# Altium schematic sheet 03 B2B and Ethernet PHY.SchDoc("03 B2B and Ethernet PHY")
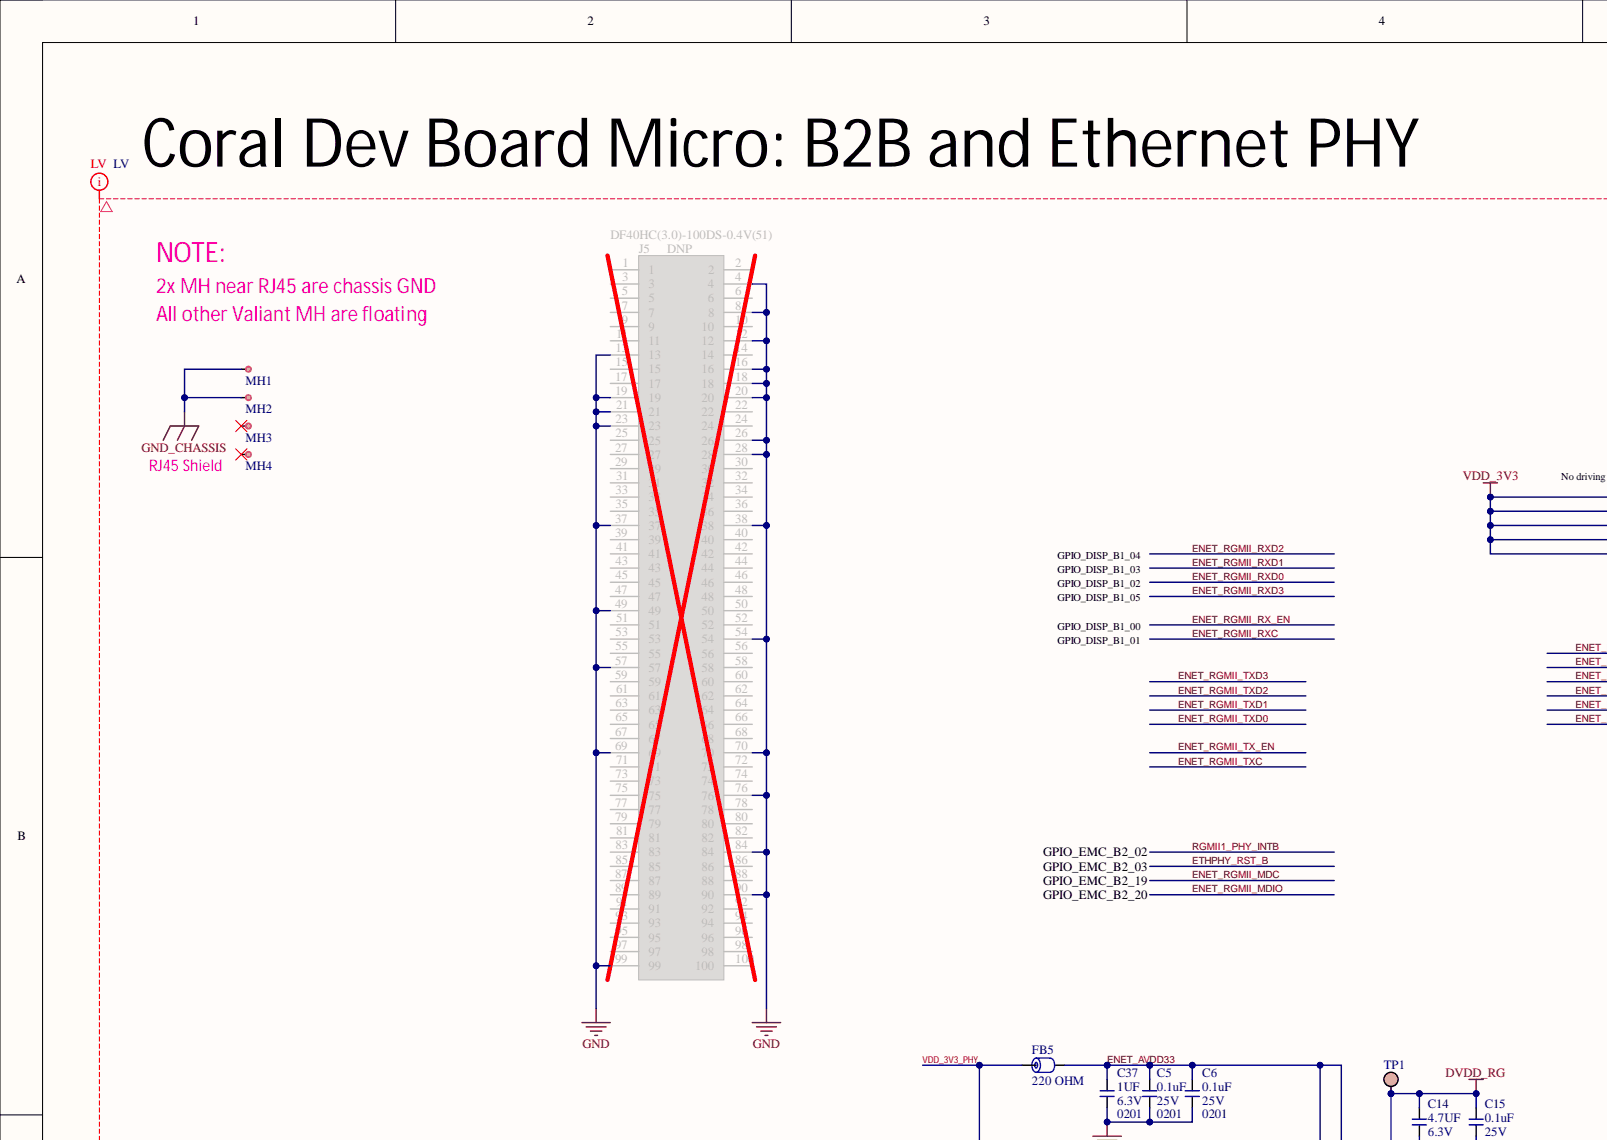
[diagram p0: 03 B2B and Ethernet PHY.SchDoc, top left]
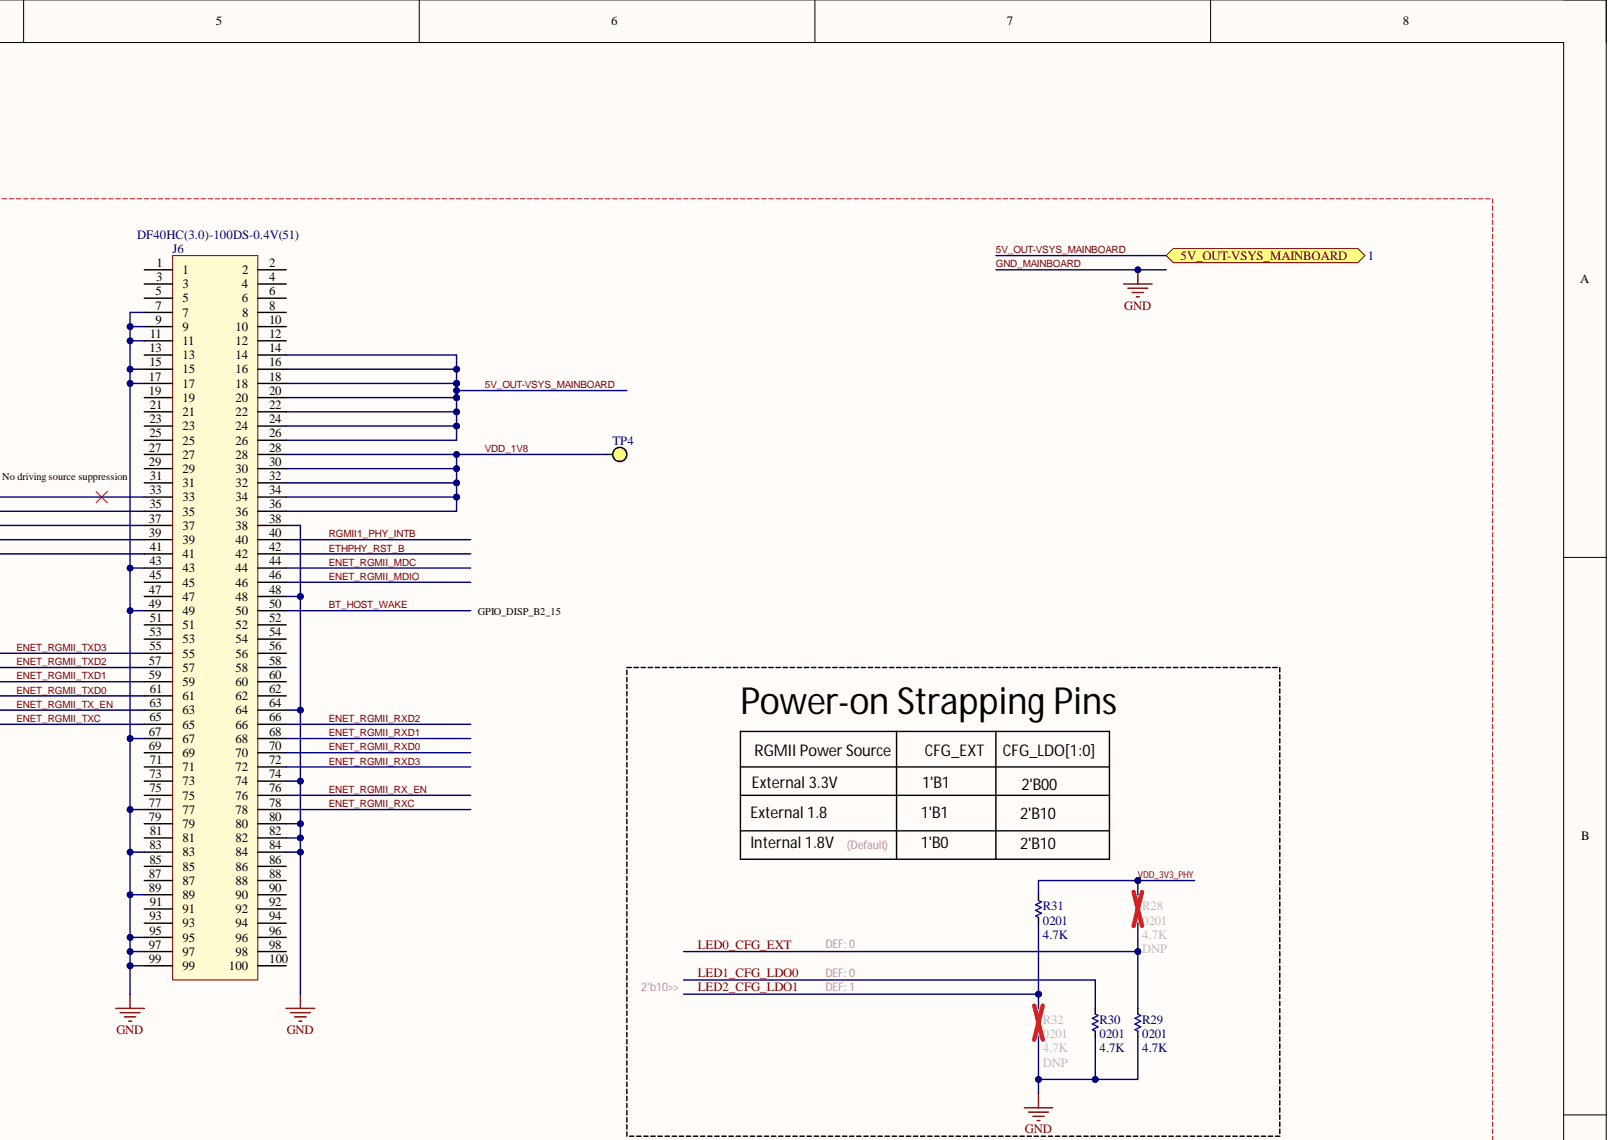
[diagram p1: 03 B2B and Ethernet PHY.SchDoc, top right]
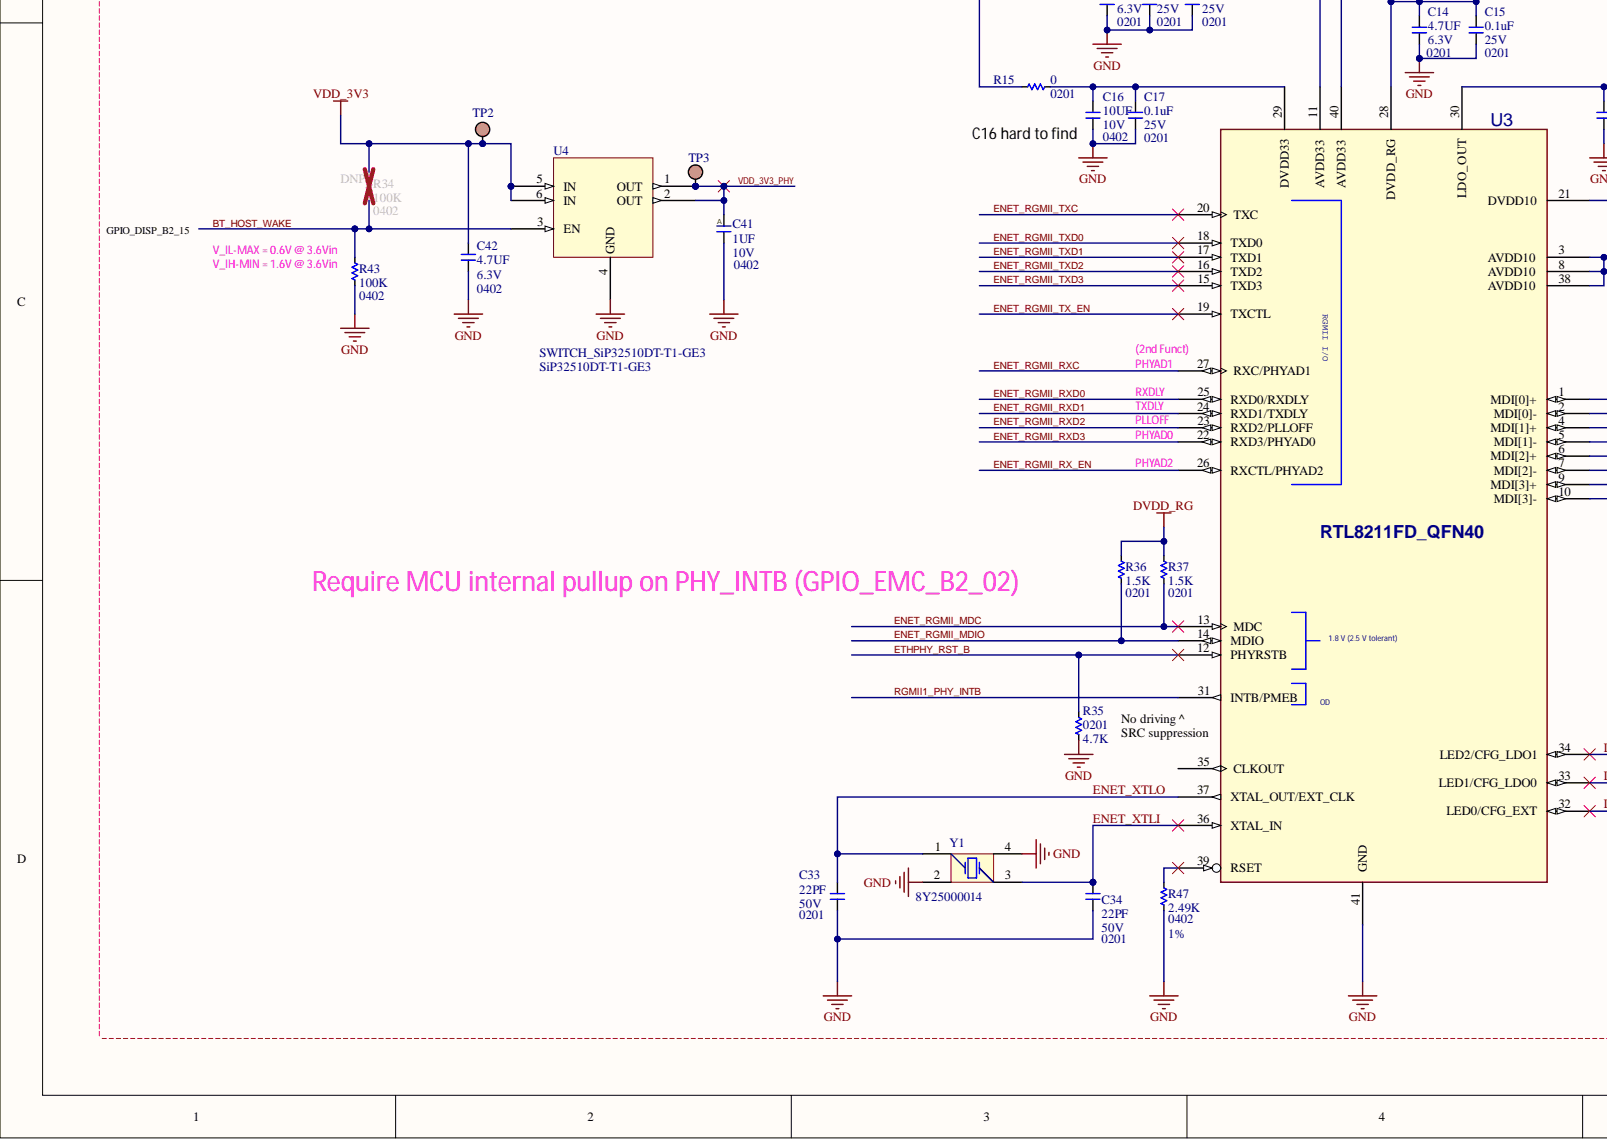
[diagram p2: 03 B2B and Ethernet PHY.SchDoc, bottom left]
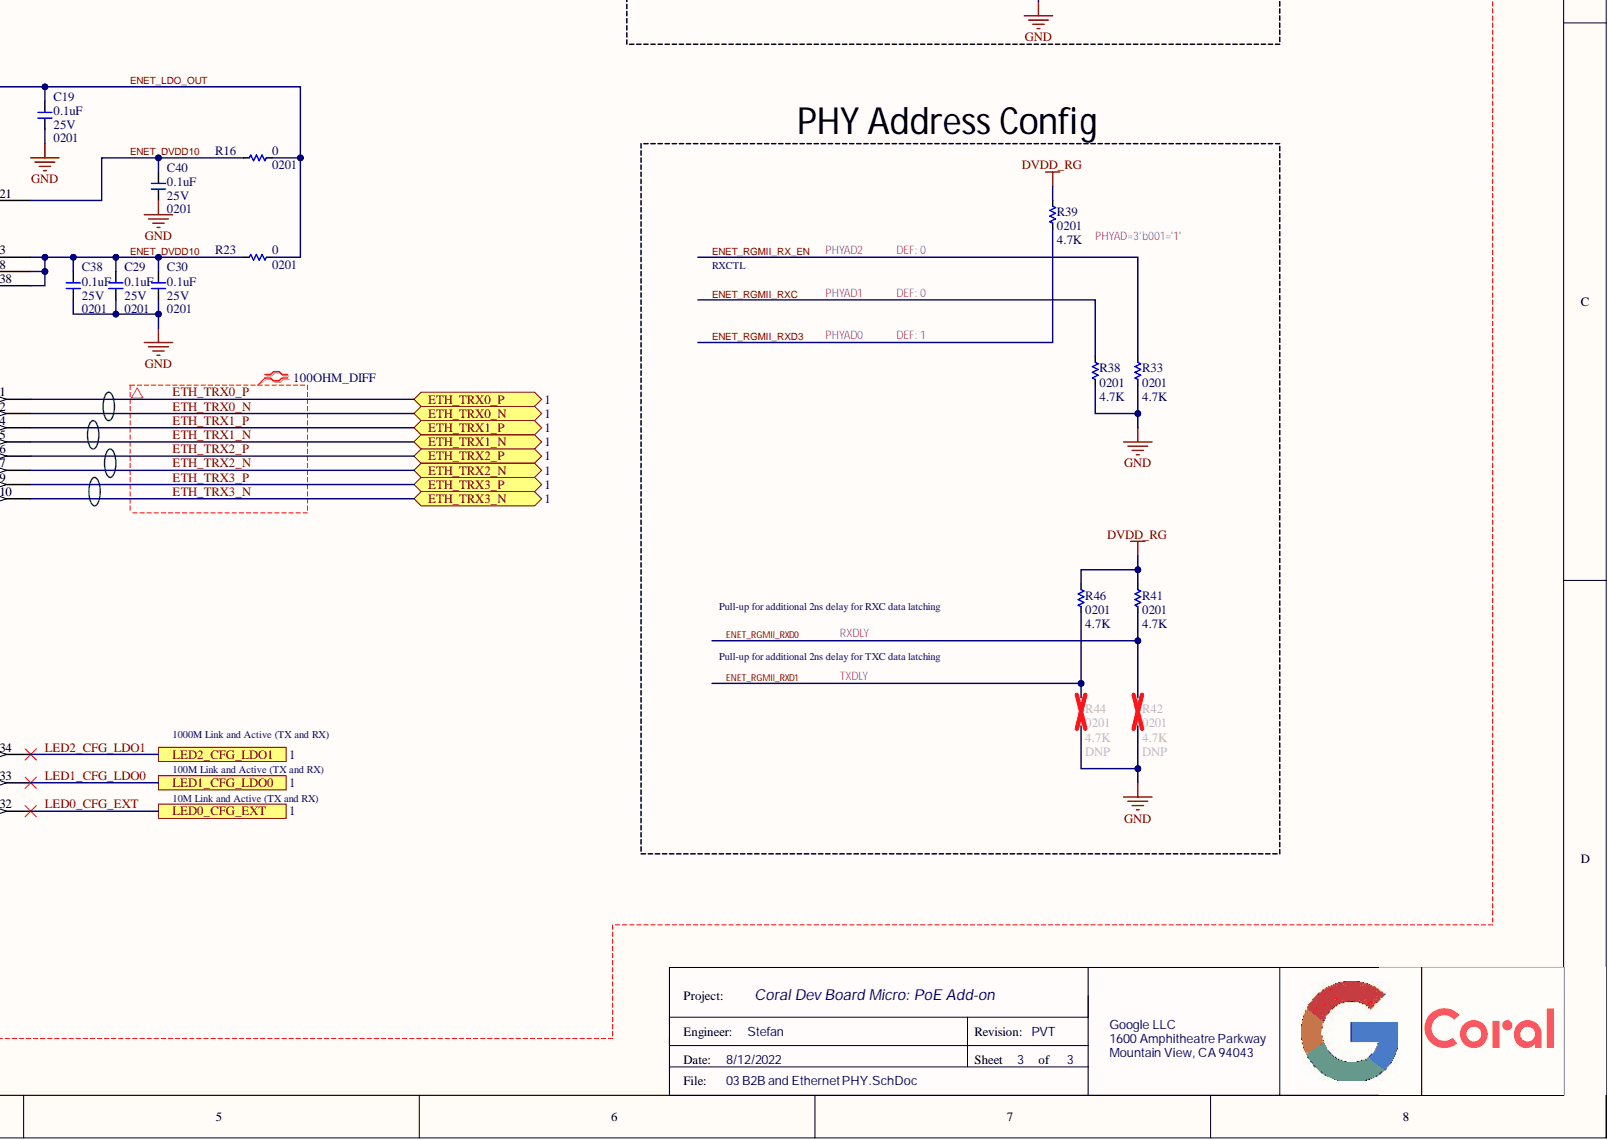
[diagram p3: 03 B2B and Ethernet PHY.SchDoc, bottom right]

## Parts
C5  value 0.1uF  Package: 0201  Voltage: 25V  [C0201Y]  (hidden comment: __Template_Cap)
C6  value 0.1uF  Package: 0201  Voltage: 25V  [C0201Y]  (hidden comment: __Template_Cap)
C14  value 4.7UF  Package: 0201  Voltage: 6.3V  [C0201Y]  (hidden comment: __Template_Cap)
C15  value 0.1uF  Package: 0201  Voltage: 25V  [C0201Y]  (hidden comment: __Template_Cap)
C16  value 10UF  Package: 0402  Voltage: 10V  [C0402]  (hidden comment: __Template_Cap)
C17  value 0.1uF  Package: 0201  Voltage: 25V  [C0201Y]  (hidden comment: __Template_Cap)
C19  value 0.1uF  Package: 0201  Voltage: 25V  [C0201Y]  (hidden comment: __Template_Cap)
C29  value 0.1uF  Package: 0201  Voltage: 25V  [C0201Y]  (hidden comment: __Template_Cap)
C30  value 0.1uF  Package: 0201  Voltage: 25V  [C0201Y]  (hidden comment: __Template_Cap)
C33  value 22PF  Package: 0201  Voltage: 50V  [C0201]  (hidden comment: __Template_Cap)
C34  value 22PF  Package: 0201  Voltage: 50V  [C0201]  (hidden comment: __Template_Cap)
C37  value 1UF  Package: 0201  Voltage: 6.3V  [C0201_H0.39MM]  (hidden comment: __Template_Cap)
C38  value 0.1uF  Package: 0201  Voltage: 25V  [C0201Y]  (hidden comment: __Template_Cap)
C40  value 0.1uF  Package: 0201  Voltage: 25V  [C0201Y]  (hidden comment: __Template_Cap)
C41  value 1UF  Package: 0402  Voltage: 10V  [C0402]  (hidden comment: __Template_Cap_Auto)
C42  value 4.7UF  Voltage: 6.3V  [C0402]  (hidden comment: __Template_Cap)
FB5  value 220 OHM  [L0402_H0.55MM]  (hidden comment: __Template_Ferrite_Bead)
J5  MFG P/N: DF40HC(3.0)-100DS-0.4V(51)  [HIROSE_DF40HC(3.0)-100DS-0.4V(51)]
J6  MFG P/N: DF40HC(3.0)-100DS-0.4V(51)  [HIROSE_DF40HC(3.0)-100DS-0.4V(51)]
MH1  PEM_SMTSO-M3-2ET  [WE_9774030951R]  (value not drawn)
MH2  PEM_SMTSO-M3-2ET  [WE_9774030951R]  (value not drawn)
MH3  PEM_SMTSO-M3-2ET  [WE_9774030951R]  (value not drawn)
MH4  PEM_SMTSO-M3-2ET  [WE_9774030951R]  (value not drawn)
R15  value 0  Package: 0201  [R0201]
R16  value 0  Package: 0201  [R0201]
R23  value 0  Package: 0201  [R0201]
R28  value 4.7K  Package: 0201  [R0201]
R29  value 4.7K  Package: 0201  [R0201]
R30  value 4.7K  Package: 0201  [R0201]
R31  value 4.7K  Package: 0201  [R0201]
R32  value 4.7K  Package: 0201  [R0201]
R33  value 4.7K  Package: 0201  [R0201]
R34  value 100K  Package: 0402  [R0402]
R35  value 4.7K  Package: 0201  [R0201]
R36  value 1.5K  Package: 0201  [R0201]
R37  value 1.5K  Package: 0201  [R0201]
R38  value 4.7K  Package: 0201  [R0201]
R39  value 4.7K  Package: 0201  [R0201]
R41  value 4.7K  Package: 0201  [R0201]
R42  value 4.7K  Package: 0201  [R0201]
R43  value 100K  Package: 0402  [R0402]
R44  value 4.7K  Package: 0201  [R0201]
R46  value 4.7K  Package: 0201  [R0201]
R47  value 2.49K  Package: 0402  [R0402]
TP1  TESTPAD_30MIL  [TESTPAD_SMT_30MIL]  (value not drawn)  (no BOM)
TP2  TESTPAD_30MIL  [TESTPAD_SMT_30MIL]  (value not drawn)  (no BOM)
TP3  TESTPAD_30MIL  [TESTPAD_SMT_30MIL]  (value not drawn)  (no BOM)
TP4  TESTPAD_30MIL  [TESTPAD_SMT_30MIL]  (value not drawn)  (no BOM)
U3  RTL8211FD_QFN40  [QFN40_127P600-8N]
U4  SWITCH_SiP32510DT-T1-GE3  MFG P/N: SiP32510DT-T1-GE3  [SOT95P285X110-6]
Y1  8Y25000014  [DFN130P250X50-4N]

## Ports
5V_OUT-VSYS_MAINBOARD, ETH_TRX0_N, ETH_TRX0_P, ETH_TRX1_N, ETH_TRX1_P, ETH_TRX2_N, ETH_TRX2_P, ETH_TRX3_N, ETH_TRX3_P, LED0_CFG_EXT, LED1_CFG_LDO0, LED2_CFG_LDO1

## Nets
DVDD_RG: C14.1 C15.1 R36.2 R37.2 R39.2 R41.2 R46.2 TP1.1 U3.28(DVDD_RG)
GND_CHASSIS: MH1.1 MH2.1
VDD_3V3: C42.1 J6.33 J6.35 J6.37 J6.39 J6.41 R34.2 TP2.1 U4.5(IN) U4.6(IN)
5V_OUT-VSYS_MAINBOARD: J6.14 J6.16 J6.18 J6.20 J6.22 J6.24 J6.26 ; port 5V_OUT-VSYS_MAINBOARD
BT_HOST_WAKE: J6.50 R34.1 R43.2 U4.3(EN)
ENET_AVDD33: C5.1 C6.1 C37.1 FB5.2 U3.11(AVDD33) U3.40(AVDD33)
ENET_DVDD10: C29.1 C30.1 C38.1 C40.1 R16.1 R23.1 U3.3(AVDD10) U3.8(AVDD10) U3.21(DVDD10) U3.38(AVDD10)
ENET_LDO_OUT: C19.1 R16.2 R23.2 U3.30(LDO_OUT)
ENET_RGMII_MDC: J6.44 R37.1 U3.13(MDC)
ENET_RGMII_MDIO: J6.46 R36.1 U3.14(MDIO)
ENET_RGMII_RX_EN: J6.76 R33.2 U3.26(RXCTL/PHYAD2)
ENET_RGMII_RXC: J6.78 R38.2 U3.27(RXC/PHYAD1)
ENET_RGMII_RXD0: J6.70 R41.1 R42.2 U3.25(RXD0/RXDLY)
ENET_RGMII_RXD1: J6.68 R44.2 R46.1 U3.24(RXD1/TXDLY)
ENET_RGMII_RXD2: J6.66 U3.23(RXD2/PLLOFF)
ENET_RGMII_RXD3: J6.72 R39.1 U3.22(RXD3/PHYAD0)
ENET_RGMII_TX_EN: J6.63 U3.19(TXCTL)
ENET_RGMII_TXC: J6.65 U3.20(TXC)
ENET_RGMII_TXD0: J6.61 U3.18(TXD0)
ENET_RGMII_TXD1: J6.59 U3.17(TXD1)
ENET_RGMII_TXD2: J6.57 U3.16(TXD2)
ENET_RGMII_TXD3: J6.55 U3.15(TXD3)
ENET_XTLI: C34.1 U3.36(XTAL_IN) Y1.3
ENET_XTLO: C33.1 U3.37(XTAL_OUT/EXT_CLK) Y1.1
ETH_TRX0_N: U3.2(MDI[0]-) ; port ETH_TRX0_N
ETH_TRX0_P: U3.1(MDI[0]+) ; port ETH_TRX0_P
ETH_TRX1_N: U3.5(MDI[1]-) ; port ETH_TRX1_N
ETH_TRX1_P: U3.4(MDI[1]+) ; port ETH_TRX1_P
ETH_TRX2_N: U3.7(MDI[2]-) ; port ETH_TRX2_N
ETH_TRX2_P: U3.6(MDI[2]+) ; port ETH_TRX2_P
ETH_TRX3_N: U3.10(MDI[3]-) ; port ETH_TRX3_N
ETH_TRX3_P: U3.9(MDI[3]+) ; port ETH_TRX3_P
ETHPHY_RST_B: J6.42 R35.1 U3.12(PHYRSTB)
GND_MAINBOARD: C5.2 C6.2 C14.2 C15.2 C16.2 C17.2 C19.2 C29.2 C30.2 C33.2 C34.2 C37.2 C38.2 C40.2 C41.2 C42.2 J5.4 J5.8 J5.12 J5.13 J5.16 J5.18 J5.19 J5.20 J5.21 J5.23 J5.26 J5.28 J5.37 J5.38 J5.49 J5.54 J5.57 J5.69 J5.70 J5.76 J5.84 J5.90 J5.99 J6.7 J6.9 J6.11 J6.15 J6.17 J6.38 J6.43 J6.48 J6.49 J6.64 J6.67 J6.74 J6.77 J6.80 J6.82 J6.83 J6.84 J6.89 J6.95 J6.97 J6.99 R29.1 R30.1 R32.1 R33.1 R35.2 R38.1 R42.1 R43.1 R44.1 R47.1 U3.41(GND) U4.4(GND) Y1.2 Y1.4
LED0_CFG_EXT: R28.1 R29.2 U3.32(LED0/CFG_EXT) ; port LED0_CFG_EXT
LED1_CFG_LDO0: R30.2 U3.33(LED1/CFG_LDO0) ; port LED1_CFG_LDO0
LED2_CFG_LDO1: R31.1 R32.2 U3.34(LED2/CFG_LDO1) ; port LED2_CFG_LDO1
RGMII1_PHY_INTB: J6.40 U3.31(INTB/PMEB)
VDD_1V8: J6.28 J6.30 J6.32 J6.34 J6.36 TP4.1
VDD_3V3_PHY: C41.1 FB5.1 R15.1 R28.2 R31.2 TP3.1 U4.1(OUT) U4.2(OUT)
NetC16_1: C16.1 C17.1 R15.2 U3.29(DVDD33)
NetR47_2: R47.2 U3.39(RSET)

Not connected: J5 1 2 3 5 6 7 9 10 11 14 15 17 22 24 25 27 29 30 31 32 33 34 35 36 39 40 41 42 43 44 45 46 47 48 50 51 52 53 55 56 58 59 60 61 62 63 64 65 66 67 68 71 72 73 74 75 77 78 79 80 81 82 83 85 86 87 88 89 91 92 93 94 95 96 97 98 100; J6 1 2 3 4 5 6 8 10 12 13 19 21 23 25 27 29 31 45 47 51 52 53 54 56 58 60 62 69 71 73 75 79 81 85 86 87 88 90 91 92 93 94 96 98 100; MH3 1; MH4 1; U3 35(CLKOUT)

## Library data of the parts
C5 C6 C15 C17 C19 C29 C30 C38 C40 = 386: CAP, CER, X5R, 0.1uF, 25V, 20%, 0201, 0.33mm T; COMMON: 150-01204-00; Database Table Name: Capacitors; MFG: MURATA; MFG P/N: GRM033R61E104ME14D; Component Kind: Standard; ComponentLink1Description: Datasheet; Dielectric: X5R; Gecko: 150-01204-00; Height: 0.33mm T; ID: 386; Library Name: AltiumReleaseLibDB.DBLib; LOON: 150-01204-00; Status: Released; Tolerance: 20%; XPN: 150-01204-00
C14 = 1199: CAP, CER, X5R, 4.7uF, 20%, 6.3V, 0201; COMMON: 150-01224-00; Database Table Name: Capacitors; MFG: MURATA; MFG P/N: GRM035R60J475ME15D; PROXY: 150-03477-00; Component Kind: Standard; ComponentLink1Description: Datasheet; Dielectric: X5R; Gecko: 150-01224-00; Height: 0.33mm T; ID: 1199; Library Name: AltiumReleaseLibDB.DBLib; LOON: 150-01224-00; Status: Released; Tolerance: 20%; XPN: 150-01224-00
C16 = 215: CAP, CER, X5R, 10uF, 10V, 20%, 0402, 0.7mm T; COMMON: 150-00376-00; Database Table Name: Capacitors; EES: 150-04565-00; MFG: SAMSUNG; MFG P/N: CL05A106MP5NUNC; PROXY: Use ID #1342; Component Kind: Standard; ComponentLink1Description: Datasheet; Dielectric: X5R; Gecko: 150-00376-00; Height: 0.7mm T; ID: 215; Library Name: AltiumReleaseLibDB.DBLib; LOON: 150-00376-00; Status: Released; Tolerance: 20%; XPN: 150-00376-00
C33 C34 = 885: CAP, CER, NP0, 22pF, 50V, 5%, 0201, 0.33mm T; COMMON: 150-00319-00; Database Table Name: Capacitors; MFG: TDK; MFG P/N: C0603C0G1H220J030BA; Component Kind: Standard; ComponentLink1Description: Datasheet; Dielectric: NP0; Height: 0.33mm T; ID: 885; Library Name: AltiumReleaseLibDB.DBLib; Status: NRND; Tolerance: 5%; XPN: 150-00319-00
C37 = 1771: CAP, CER, X6S, 1uF, 20%, 6.3V, 0201, 0.39mm; Database Table Name: Capacitors; EPIC: G150-02531-00; MFG: MURATA; MFG P/N: GRM033C80J105ME05D; Component Kind: Standard; ComponentLink1Description: Datasheet; ComponentLink1URL: https://psearch.en.murata.com/capacitor/product/GRM033C80J105ME05%23.pdf; Dielectric: X6S; Height: 0.39mm; HelpURL: https://www.digikey.com/product-detail/en/murata-electronics-north-america/GRM033C80J105ME05D/490-13218-1-ND/5877426; ID: 1771; Library Name: AltiumReleaseLibDB.DBLib; Status: In Development; Supplier 1: Digi-Key; Supplier Part Number 1: 490-13218-1-ND; Tolerance: 20%
C41 = 26: CAP, CER, X5R, 1uF, 10V, 10%, 0402, AUTOGRADE; COMMON: 150-01126-00; Database Table Name: Capacitors; Gecko: 150-04432-00; MFG: Taiyo Yuden; MFG P/N: LMK105BJ105KVHF; PROXY: Use ID #574; Component Kind: Standard; ComponentLink1Description: Datasheet; Dielectric: X5R; Height: 0.55mm; ID: 26; Library Name: AltiumReleaseLibDB.DBLib; Status: Released; Supplier 1: Digi-Key; Supplier Part Number 1: 587-3712-1-ND; Tolerance: 10%; XPN: 150-01126-00
C42 = 653: CAP, CER, X5R, 4.7uF, 10%, 6.3V, 0402; COMMON: 150-00307-00; Database Table Name: Capacitors; MFG: TDK; MFG P/N: C1005X5R0J475K050BC; PROXY: 150-03636-00; Component Kind: Standard; ComponentLink1Description: Datasheet; Dielectric: X5R; Height: 0.71mm T; ID: 653; Library Name: AltiumReleaseLibDB.DBLib; Package: 0402; Status: Released; Tolerance: 10%; XPN: 150-00307-00
FB5 = 917: FERRITE, BEAD, 220 OHMS@100MHZ, 25%, 700mA, 280 mOHM DCR, 0402; Catagory: Ferrite Bead; Database Table Name: Inductors/Coils/Chokes; MFG: Murata Electronics; MFG P/N: BLM15EG221SN1D; Component Kind: Standard; ComponentLink1Description: Datasheet; ComponentLink1URL: https://www.murata.com/en-us/products/productdata/8796750970910/ENFA0023.pdf; Height: 0.55mm; HelpURL: https://www.digikey.com/product-detail/en/murata-electronics/BLM15EG221SN1D/490-4005-1-ND/1016265; ID: 917; Library Name: AltiumReleaseLibDB.DBLib; Package: 0402; Status: Released; Supplier 1: Digi-Key; Supplier Part Number 1: 490-4005-1-ND
J5 J6 = 1252: CONN, RCPT, CENTER STRIP, 100 P, 0.4MM PITCH, 2.9MM H, GOLD, SMD; Connector Type: Receptacle, Center Strip Contacts; Database Table Name: Connectors; MFG: Hirose Electric Co Ltd; Number of Positions: 100; Pitch: 0.40mm; Sub Assy Qty 2: 0; Component Kind: Standard; ComponentLink1Description: Datasheet; ComponentLink1URL: https://drive.google.com/file/d/1CAt5l5Yqq5tcten62VZOFv5_vQ-Vqtjc/view; Height: 3.05mm; HelpURL: https://www.digikey.com/en/products/detail/hirose-electric-co-ltd/DF40HC-3-0-100DS-0-4V-51/4282911?s=N4IgTCBcDaICIDEAsAGAEgYQBQGYB0KAlALQCMKKcAysSnkgGpYCsphIAugL5A; ID: 1252; Library Name: AltiumReleaseLibDB.DBLib; Mounting Type: Surface Mount; Number of Rows: 2; RoHS Compliant: Yes; Status: Released; Sub Assy Qty 3: 0; Sub Assy Qty 4: 0; Supplier 1: Digi-Key; Supplier Part Number 1: DF40HC(3.0)-100DS-0.4V(51-ND
  J5: DNP: DNP
MH1-MH4 = 123: HW, BOARD SPACER, ROUND, UNTHREADED, FEMALE, 2.72MM IN DIA, 5.1MM OUT DIA, STEEL; Database Table Name: Mechanical; MFG: Würth Elektronik; MFG P/N: 9774030951R; Component Kind: Standard; ComponentLink1Description: Datasheet; ComponentLink1URL: https://www.digikey.com/en/products/detail/w%C3%BCrth-elektronik/9774030951R/5320629?s=N4IgTCBcDaIJwHYEBYAMBmVcCsBGASiALoC%2BQA; Designator: MH?; Height: 3.1mm; ID: 123; Library Name: AltiumReleaseLibDB.DBLib; RoHS Compliant: RoHS Compliant; Supplier 1: Digi-Key; Supplier Part Number 1: 732-7086-2-ND
R15 R16 R23 = 6940: RES, 0.0, 1/20W, 0201; COMMON: 470-00013-00; Database Table Name: Resistors; MFG: WALSIN TECHNOLOGY; MFG P/N: WR02X000PAL; PROXY: 470-10447-00; Tolerance: JUMPER; Component Kind: Standard; ID: 6940; Library Name: AltiumReleaseLibDB.DBLib; Power: 1/20W; Status: Released; XPN: 470-00013-00
R28-R33 R35 R38 R39 R41 R42 R44 R46 = 6602: RES, 4.7K, 5%, 1/20W, 0201; COMMON: 470-00012-00; Database Table Name: Resistors; MFG: YAGEO; MFG P/N: RC0201JR-074K7L; Component Kind: Standard; ID: 6602; Library Name: AltiumReleaseLibDB.DBLib; Power: 1/20W; Status: Released; Tolerance: 5%; XPN: 470-00012-00
  R28 R32 R42 R44: DNP: DNP
R34 R43 = 4977: RES, 100K, 1%, 1/16W, 0402; COMMON: 470-00580-00; Database Table Name: Resistors; KOI: 470-17076-00; MFG: YAGEO; MFG P/N: RC0402FR-07100KL; PROXY: 470-16075-00; Component Kind: Standard; Height: 0.40mm; ID: 4977; Library Name: AltiumReleaseLibDB.DBLib; Power: 1/16W; Status: Released; Supplier 1: Digi-Key; Supplier Part Number 1: 311-100KLRCT-ND; Tolerance: 1%; XPN: 470-00580-00
  R34: DNP: DNP
R36 R37 = 6278: RES, 1.5K, 5%, 1/20W, 0201; COMMON: 470-00027-00; Database Table Name: Resistors; MFG: YAGEO; MFG P/N: RC0201JR-071K5L; Component Kind: Standard; Height: 0.26mm; ID: 6278; Library Name: AltiumReleaseLibDB.DBLib; Power: 1/20W; Status: Released; Tolerance: 5%; XPN: 470-00027-00
R47 = 9121: RES, 2.49K, 1%, 1/16W, 0402; Database Table Name: Resistors; MFG: Yageo; MFG P/N: RC0402FR-072K49L; PROXY: 470-16503-00; Component Kind: Standard; ComponentLink1Description: Datasheet; ComponentLink1URL: http://www.yageo.com/documents/recent/PYu-RC_Group_51_RoHS_L_10.pdf; Height: 0.40mm; HelpURL: https://www.digikey.in/product-detail/en/yageo/RC0402FR-072K49L/311-2.49KLRCT-ND/2827900; ID: 9121; Library Name: AltiumReleaseLibDB.DBLib; Power: 1/16W; Status: Released; Supplier 1: Digi-Key; Supplier Part Number 1: 311-2.49KLRCT-ND; Tolerance: 1%
TP1-TP4 = 178: TESTPAD_SMT_30MIL; Database Table Name: Miscellaneous; MFG: _PCB Feature; ComponentLink1URL: _PCB Feature; ID: 178; Library Name: AltiumReleaseLibDB.DBLib; Status: Released
U3 = RTL8211FD_QFN40: IC XCVR ETHERNET PHY 10/100/1000G-BIT 3.3V QFN40; Manufacture 1: RealTek; Manufacture Part Number 1: RTL8211FD-CG; Component Kind: Standard; HEIGHT: 0.85MM; ROHS: Yes; Supplier 1: Arrow; Library Name: SpacePark.SchLib
U4 = 655: IC, SW, LOAD, SIP32510DT-T1-GE3, N-CH, 1.2 TO 5.5 VL, 3A IO, SOT23-6; Database Table Name: IC - PMIC; MFG: Vishay Siliconix; Component Kind: Standard; ComponentLink1Description: Datasheet; ComponentLink1URL: http://www.vishay.com/docs/63577/sip32510.pdf; HelpURL: https://www.digikey.in/product-detail/en/vishay-siliconix/SIP32510DT-T1-GE3/SIP32510DT-T1-GE3CT-ND/6230055; ID: 655; Library Name: AltiumReleaseLibDB.DBLib; Mounting Type: SMT; Package: TSOT-23-6; RoHS Compliant: Yes; Status: Released; Supplier 1: Digi-Key; Supplier Part Number 1: SIP32510DT-T1-GE3CT-ND
Y1 = XTAL_25MHZ_ABM10AIG-25.000MHZ-4Z-T3: Crystal 25.0000MHz 30ppm 10pF 60 Ohm -40蚓 - 125蚓 Surface Mount 4-SMD, No Lead (DFN, LCC); Function Code: LAN; QPN: BG6250000B5; QPN Description: XTAL SMD 25MHZ(+-10PPM,16PF)8Y25000014; Component Kind: Standard; MPN: 8Y25000014; QCI MPN: 8Y25000014; QCI Supplier: TXC; Supplier 1: Digi-Key; Supplier Part Number 1: 535-13365-1-ND; Library Name: links.SchLib
